# Altium schematic sheet DC_DRIVERS.SchDoc
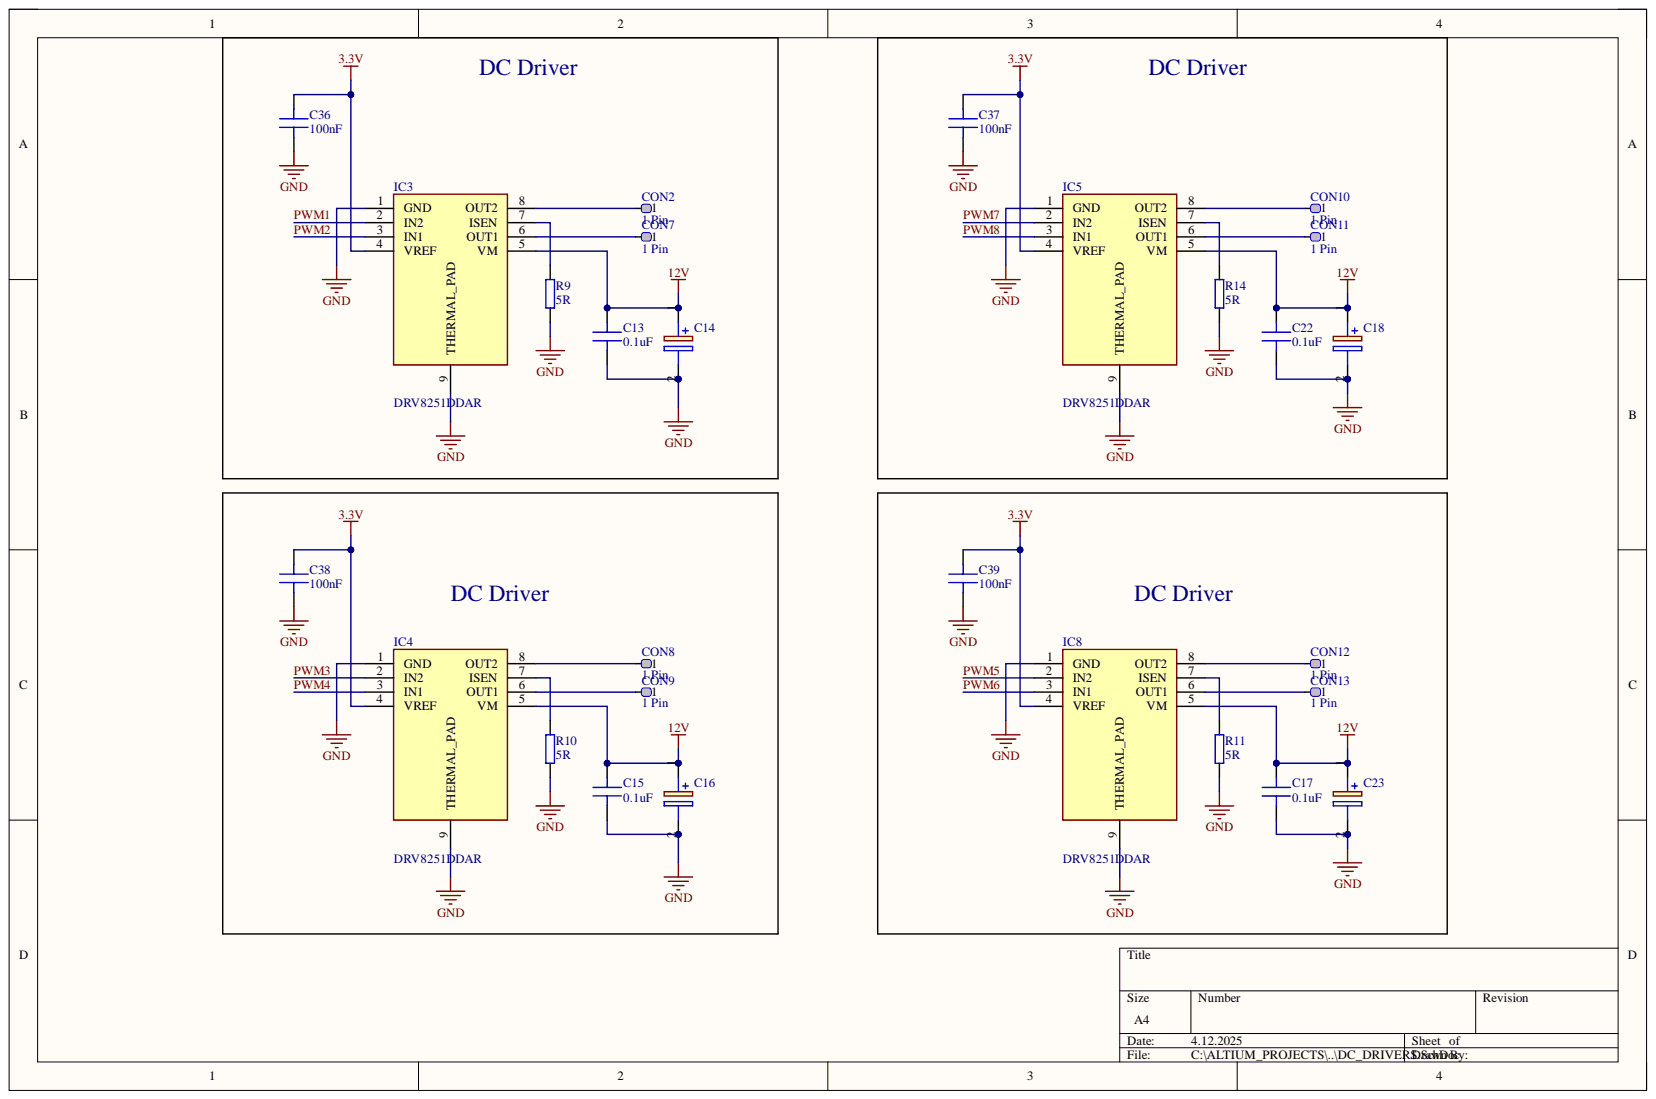
[diagram p0: DC_DRIVERS.SchDoc]

## Parts
C13  0.1uF  [C 0402]
C14  UVY1V101MED1TD  [CAPPRD250W50D655H1250]  (value not drawn)
C15  0.1uF  [C 0603]
C16  UVY1V101MED1TD  [CAPPRD250W50D655H1250]  (value not drawn)
C17  0.1uF  [C 0402]
C18  UVY1V101MED1TD  [CAPPRD250W50D655H1250]  (value not drawn)
C22  0.1uF  [C 0402]
C23  UVY1V101MED1TD  [CAPPRD250W50D655H1250]  (value not drawn)
C36  100nF  [C 0402]
C37  100nF  [C 0402]
C38  100nF  [C 0402]
C39  100nF  [C 0402]
CON2  1 Pin  [SMD PAD]
CON7  1 Pin  [SMD PAD]
CON8  1 Pin  [SMD PAD]
CON9  1 Pin  [SMD PAD]
CON10  1 Pin  [SMD PAD]
CON11  1 Pin  [SMD PAD]
CON12  1 Pin  [SMD PAD]
CON13  1 Pin  [SMD PAD]
IC3  DRV8251DDAR  [SOIC127P600X170-9N]
IC4  DRV8251DDAR  [SOIC127P600X170-9N]
IC5  DRV8251DDAR  [SOIC127P600X170-9N]
IC8  DRV8251DDAR  [SOIC127P600X170-9N]
R9  5R  [R 1206]
R10  5R  [R 1206]
R11  5R  [R 1206]
R14  5R  [R 1206]

## Nets
3.3V: C36.1 C37.1 C38.1 C39.1 IC3.4(VREF) IC4.4(VREF) IC5.4(VREF) IC8.4(VREF)
12V: C13.1 C14.1 C15.1 C16.1 C17.1 C18.1 C22.1 C23.1 IC3.5(VM) IC4.5(VM) IC5.5(VM) IC8.5(VM)
GND: C13.2 C14.2 C15.2 C16.2 C17.2 C18.2 C22.2 C23.2 C36.2 C37.2 C38.2 C39.2 IC3.1(GND) IC3.9(THERMAL_PAD) IC4.1(GND) IC4.9(THERMAL_PAD) IC5.1(GND) IC5.9(THERMAL_PAD) IC8.1(GND) IC8.9(THERMAL_PAD) R9.2 R10.2 R11.2 R14.2
PWM1: IC3.2(IN2)
PWM2: IC3.3(IN1)
PWM3: IC4.2(IN2)
PWM4: IC4.3(IN1)
PWM5: IC8.2(IN2)
PWM6: IC8.3(IN1)
PWM7: IC5.2(IN2)
PWM8: IC5.3(IN1)
NetCON2_1: CON2.1 IC3.8(OUT2)
NetCON7_1: CON7.1 IC3.6(OUT1)
NetCON8_1: CON8.1 IC4.8(OUT2)
NetCON9_1: CON9.1 IC4.6(OUT1)
NetCON10_1: CON10.1 IC5.8(OUT2)
NetCON11_1: CON11.1 IC5.6(OUT1)
NetCON12_1: CON12.1 IC8.8(OUT2)
NetCON13_1: CON13.1 IC8.6(OUT1)
NetIC3_7: IC3.7(ISEN) R9.1
NetIC4_7: IC4.7(ISEN) R10.1
NetIC5_7: IC5.7(ISEN) R14.1
NetIC8_7: IC8.7(ISEN) R11.1

## Library data of the parts
C13 C15 C17 C22 C36-C39 = Capacitor: MLCC Capacitor; Component Kind: Standard; Library Name: Components.IntLib
C14 C16 C18 C23 = UVY1V101MED1TD: Capacitor Polarised; Manufacturer_Name: Nichicon; Arrow Part Number: UVY1V101MED1TD; Arrow Price/Stock: https://www.arrow.com/en/products/uvy1v101med1td/nichicon; Component Kind: Standard; Datasheet Link: http://nichicon-us.com/english/products/pdfs/e-uvy.pdf; Height: 12.5mm; Manufacturer_Part_Number: UVY1V101MED1TD; Library Name: caravan_sch_lib.SchLib
IC3-IC5 IC8 = DRV8251DDAR: Integrated Circuit; Manufacturer_Name: Texas Instruments; Arrow Part Number: DRV8251DDAR; Arrow Price/Stock: https://www.arrow.com/en/products/drv8251ddar/texas-instruments?region=nac; Component Kind: Standard; Datasheet Link: https://www.arrow.com/en/products/drv8251ddar/texas-instruments?region=nac; Height: 1.7mm; Manufacturer_Part_Number: DRV8251DDAR; Mouser Part Number: 595-DRV8251DDAR; Mouser Price/Stock: https://www.mouser.co.uk/ProductDetail/Texas-Instruments/DRV8251DDAR?qs=doiCPypUmgHTzZyfapGahQ%3D%3D; Library Name: caravan_sch_lib.SchLib
R9-R11 R14 = Resistor: General pourpouse resistor; Component Kind: Standard; Library Name: Components.IntLib
CON2 CON7-CON13 = 1 Pin: Component Kind: Standard; Library Name: Connectors.IntLib
